# Altium schematic sheet PERIPHERAL_PORT_IO.SchDoc
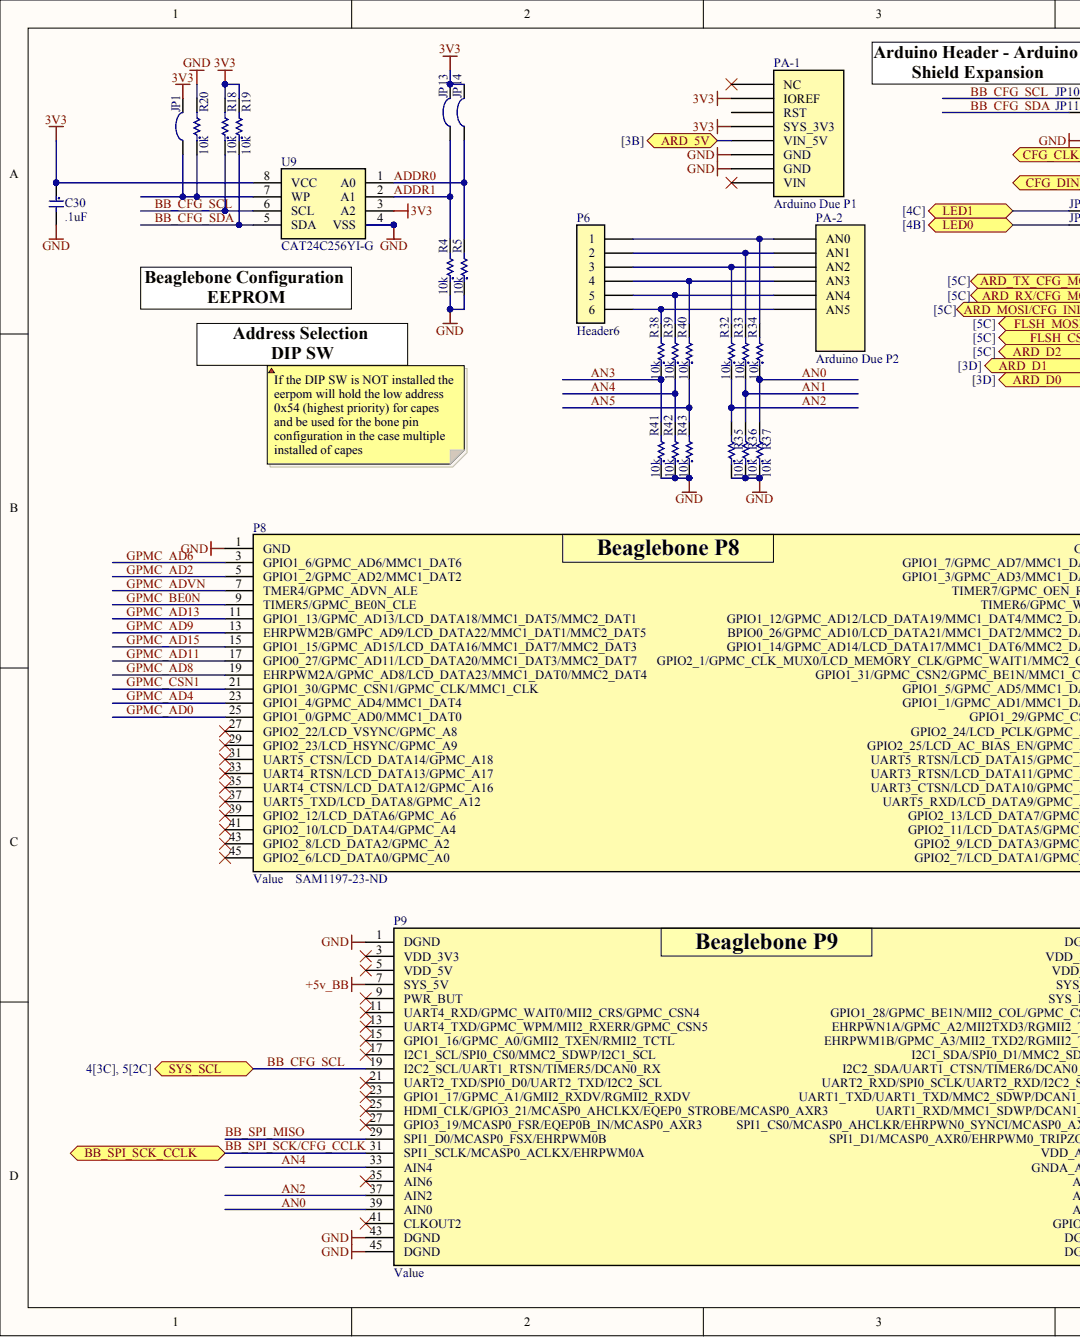
[diagram p0: PERIPHERAL_PORT_IO.SchDoc, left]
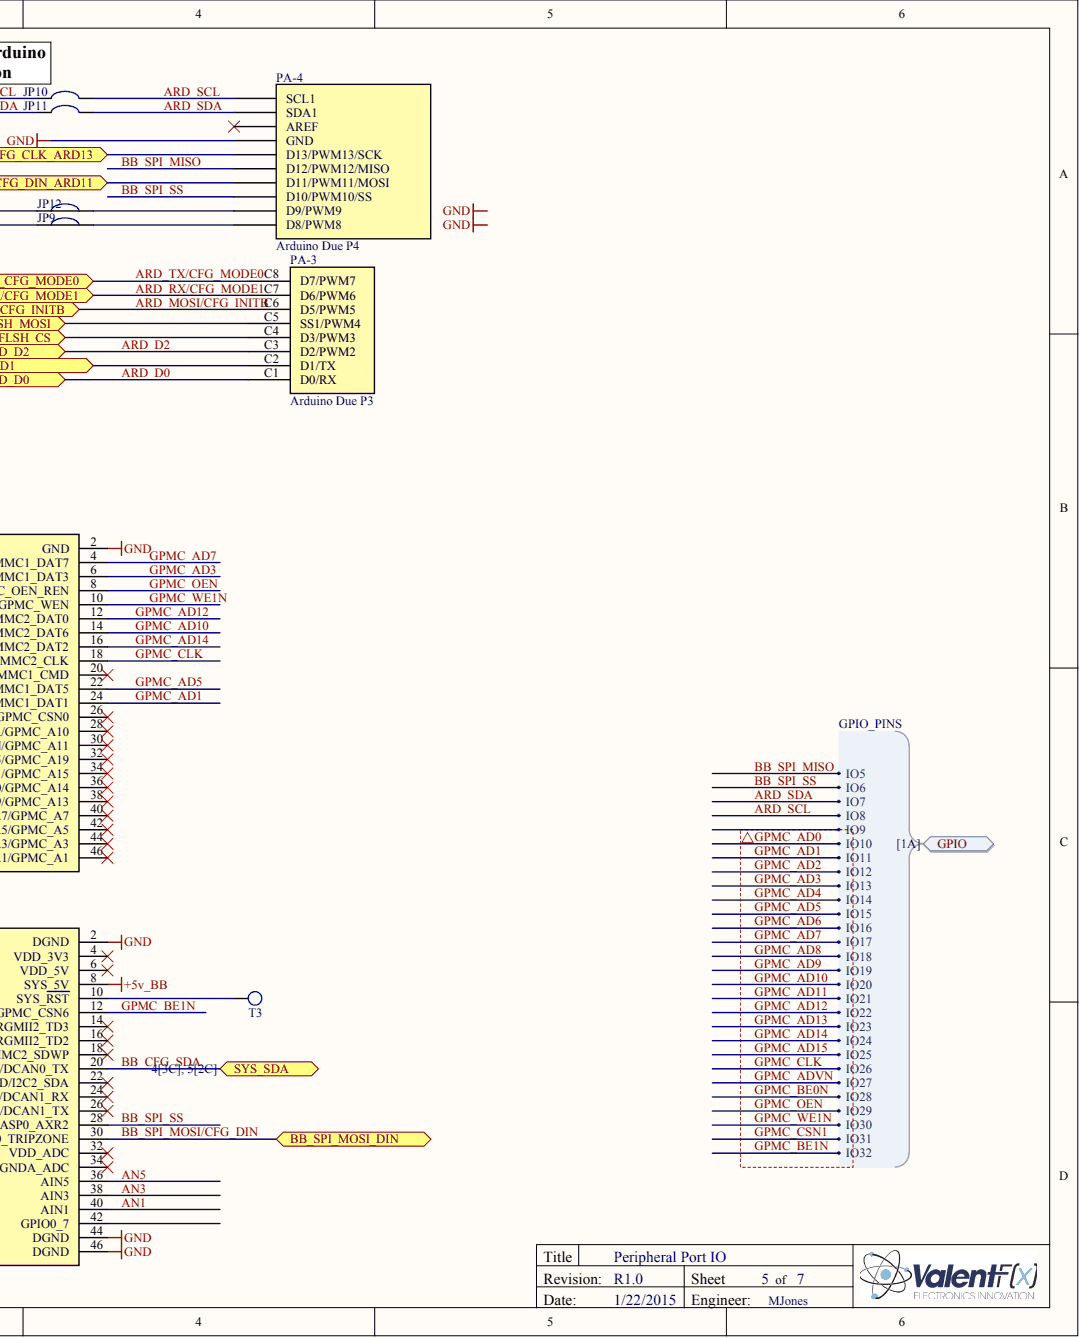
[diagram p1: PERIPHERAL_PORT_IO.SchDoc, right]

## Parts
C30  .1uF  [c0603]
JP1  Solder Jumper  [SOLDER_JUMPER]  (value not drawn)
JP9  Solder Jumper-NC  [SOLDER_JUMPER-NC]  (value not drawn)
JP10  Solder Jumper  [SOLDER_JUMPER]  (value not drawn)
JP11  Solder Jumper  [SOLDER_JUMPER]  (value not drawn)
JP12  Solder Jumper-NC  [SOLDER_JUMPER-NC]  (value not drawn)
JP13  Solder Jumper  [SOLDER_JUMPER]  (value not drawn)
JP14  Solder Jumper  [SOLDER_JUMPER]  (value not drawn)
P6  Header6  [HEADER_6_40_100 THRU SIP]  (no BOM)
P8  Value  Supplier Part Number 1: SAM1197-23-ND  [Beaglebone-SSQ]
P9  Value  [Beaglebone-SSQ]
PA-1  Arduino Due P1  [Arduino Due P1]
PA-2  Arduino Due P2  [Arduino Due P2]
PA-3  Arduino Due P3  [Arduino Due P3]
PA-4  Arduino Due P4  [Arduino Due P4]
R4  10k  [R0603]
R5  10k  [R0603]
R18  10k  [R0603]
R19  10k  [R0603]
R20  10k  [R0603]
R32  10k  [R0603]
R33  10k  [R0603]
R34  10k  [R0603]
R35  10k  [R0603]
R36  10k  [R0603]
R37  10k  [R0603]
R38  10k  [R0603]
R39  10k  [R0603]
R40  10k  [R0603]
R41  10k  [R0603]
R42  10k  [R0603]
R43  10k  [R0603]
T3  Test Point  [Tp 25-40]  (value not drawn)  (no BOM)
U9  CAT24C256YI-G  [TSSOP 8]

## Ports
ARD_5V, ARD_D0, ARD_D1, ARD_D2, ARD_MOSI/CFG_INITB, ARD_RX/CFG_MODE1, ARD_TX_CFG_MODE0, BB_SPI_MOSI_DIN, BB_SPI_SCK_CCLK, CFG_CLK_ARD13, CFG_DIN_ARD11, FLSH_CS, FLSH_MOSI, GPIO, GPIO.IO5, GPIO.IO6, GPIO.IO7, GPIO.IO8, GPIO.IO9, GPIO.IO10, GPIO.IO11, GPIO.IO12, GPIO.IO13, GPIO.IO14, GPIO.IO15, GPIO.IO16, GPIO.IO17, GPIO.IO18, GPIO.IO19, GPIO.IO20, GPIO.IO21, GPIO.IO22, GPIO.IO23, GPIO.IO24, GPIO.IO25, GPIO.IO26, GPIO.IO27, GPIO.IO28, GPIO.IO29, GPIO.IO30, GPIO.IO31, GPIO.IO32, LED0, LED1, SYS_SCL, SYS_SDA

## Nets
3V3: C30.1 JP1.2 JP13.2 JP14.2 PA-1.A2(IOREF) PA-1.A4(SYS_3V3) R18.2 R19.2 U9.3(A2) U9.8(VCC)
+5V_BB: P9.7(SYS_5V) P9.8(SYS_5V)
GND: C30.2 P8.1(GND) P8.2(GND) P9.1(DGND) P9.2(DGND) P9.43(DGND) P9.44(DGND) P9.45(DGND) P9.46(DGND) PA-1.A6(GND) PA-1.A7(GND) PA-4.D7(GND) R4.1 R5.1 R20.2 R35.1 R36.1 R37.1 R41.1 R42.1 R43.1 U9.4(VSS)
ADDR0: JP14.1 R5.2 U9.1(A0)
ADDR1: JP13.1 R4.2 U9.2(A1)
AN0: P9.39(AIN0) R34.1 R37.2
AN1: P9.40(AIN1) R33.1 R36.2
AN2: P9.37(AIN2) R32.1 R35.2
AN3: P9.38(AIN3) R38.1 R41.2
AN4: P9.33(AIN4) R39.1 R42.2
AN5: P9.36(AIN5) R40.1 R43.2
ARD_5V: PA-1.A5(VIN_5V) ; port ARD_5V
ARD_D0: PA-3.C1(D0/RX) ; port ARD_D0
ARD_D1: PA-3.C2(D1/TX) ; port ARD_D1
ARD_D2: PA-3.C3(D2/PWM2) ; port ARD_D2
ARD_MOSI/CFG_INITB: PA-3.C6(D5/PWM5) ; port ARD_MOSI/CFG_INITB
ARD_RX/CFG_MODE1: PA-3.C7(D6/PWM6) ; port ARD_RX/CFG_MODE1
ARD_SCL: JP10.2 PA-4.D10(SCL1) ; port GPIO
ARD_SDA: JP11.2 PA-4.D9(SDA1) ; port GPIO
ARD_TX/CFG_MODE0: PA-3.C8(D7/PWM7) ; port ARD_TX_CFG_MODE0
BB_CFG_SCL: JP10.1 P9.19(I2C2_SCL/UART1_RTSN/TIMER5/DCAN0_RX) R18.1 U9.6(SCL) ; port SYS_SCL
BB_CFG_SDA: JP11.1 P9.20(I2C2_SDA/UART1_CTSN/TIMER6/DCAN0_TX) R19.1 U9.5(SDA) ; port SYS_SDA
BB_SPI_MISO: P9.29(SPI1_D0/MCASP0_FSX/EHRPWM0B) PA-4.D5(D12/PWM12/MISO) ; port GPIO
BB_SPI_MOSI/CFG_DIN: P9.30(SPI1_D1/MCASP0_AXR0/EHRPWM0_TRIPZONE) ; port BB_SPI_MOSI_DIN
BB_SPI_SCK/CFG_CCLK: P9.31(SPI1_SCLK/MCASP0_ACLKX/EHRPWM0A) ; port BB_SPI_SCK_CCLK
BB_SPI_SS: P9.28(SPI1_CS0/MCASP0_AHCLKR/EHRPWN0_SYNCI/MCASP0_AXR2) PA-4.D3(D10/PWM10/SS) ; port GPIO
CFG_CLK_ARD13: PA-4.D6(D13/PWM13/SCK) ; port CFG_CLK_ARD13
CFG_DIN_ARD11: PA-4.D4(D11/PWM11/MOSI) ; port CFG_DIN_ARD11
FLSH_CS: PA-3.C4(D3/PWM3) ; port FLSH_CS
FLSH_MOSI: PA-3.C5(SS1/PWM4) ; port FLSH_MOSI
GPMC_AD0: P8.25(GPIO1_0/GPMC_AD0/MMC1_DAT0) ; port GPIO
GPMC_AD1: P8.24(GPIO1_1/GPMC_AD1/MMC1_DAT1) ; port GPIO
GPMC_AD2: P8.5(GPIO1_2/GPMC_AD2/MMC1_DAT2) ; port GPIO
GPMC_AD3: P8.6(GPIO1_3/GPMC_AD3/MMC1_DAT3) ; port GPIO
GPMC_AD4: P8.23(GPIO1_4/GPMC_AD4/MMC1_DAT4) ; port GPIO
GPMC_AD5: P8.22(GPIO1_5/GPMC_AD5/MMC1_DAT5) ; port GPIO
GPMC_AD6: P8.3(GPIO1_6/GPMC_AD6/MMC1_DAT6) ; port GPIO
GPMC_AD7: P8.4(GPIO1_7/GPMC_AD7/MMC1_DAT7) ; port GPIO
GPMC_AD8: P8.19(EHRPWM2A/GPMC_AD8/LCD_DATA23/MMC1_DAT0/MMC2_DAT4) ; port GPIO
GPMC_AD9: P8.13(EHRPWM2B/GMPC_AD9/LCD_DATA22/MMC1_DAT1/MMC2_DAT5) ; port GPIO
GPMC_AD10: P8.14(BPIO0_26/GPMC_AD10/LCD_DATA21/MMC1_DAT2/MMC2_DAT6) ; port GPIO
GPMC_AD11: P8.17(GPIO0_27/GPMC_AD11/LCD_DATA20/MMC1_DAT3/MMC2_DAT7) ; port GPIO
GPMC_AD12: P8.12(GPIO1_12/GPMC_AD12/LCD_DATA19/MMC1_DAT4/MMC2_DAT0) ; port GPIO
GPMC_AD13: P8.11(GPIO1_13/GPMC_AD13/LCD_DATA18/MMC1_DAT5/MMC2_DAT1) ; port GPIO
GPMC_AD14: P8.16(GPIO1_14/GPMC_AD14/LCD_DATA17/MMC1_DAT6/MMC2_DAT2) ; port GPIO
GPMC_AD15: P8.15(GPIO1_15/GPMC_AD15/LCD_DATA16/MMC1_DAT7/MMC2_DAT3) ; port GPIO
GPMC_ADVN: P8.7(TMER4/GPMC_ADVN_ALE) ; port GPIO
GPMC_BE0N: P8.9(TIMER5/GPMC_BE0N_CLE) ; port GPIO
GPMC_BE1N: P9.12(GPIO1_28/GPMC_BE1N/MII2_COL/GPMC_CSN6) ; port GPIO
GPMC_CLK: P8.18(GPIO2_1/GPMC_CLK_MUX0/LCD_MEMORY_CLK/GPMC_WAIT1/MMC2_CLK) ; port GPIO
GPMC_CSN1: P8.21(GPIO1_30/GPMC_CSN1/GPMC_CLK/MMC1_CLK) ; port GPIO
GPMC_OEN: P8.8(TIMER7/GPMC_OEN_REN) ; port GPIO
GPMC_WE1N: P8.10(TIMER6/GPMC_WEN) ; port GPIO
LED0: JP9.1 ; port LED0
LED1: JP12.1 ; port LED1
NetJP1_1: JP1.1 R20.1 U9.7(WP)
NetJP9_2: JP9.2 PA-4.D1(D8/PWM8)
NetJP12_2: JP12.2 PA-4.D2(D9/PWM9)
NetP6_1: P6.1 PA-2.B1(AN0) R34.2
NetP6_2: P6.2 PA-2.B2(AN1) R33.2
NetP6_3: P6.3 PA-2.B3(AN2) R32.2
NetP6_4: P6.4 PA-2.B4(AN3) R40.2
NetP6_5: P6.5 PA-2.B5(AN4) R39.2
NetP6_6: P6.6 PA-2.B6(AN5) R38.2
NetP9_10: P9.10(SYS_RST) T3.1
N00230: ; port GPIO

Not connected: P8 20(GPIO1_31/GPMC_CSN2/GPMC_BE1N/MMC1_CMD) 26(GPIO1_29/GPMC_CSN0) 27(GPIO2_22/LCD_VSYNC/GPMC_A8) 28(GPIO2_24/LCD_PCLK/GPMC_A10) 29(GPIO2_23/LCD_HSYNC/GPMC_A9) 30(GPIO2_25/LCD_AC_BIAS_EN/GPMC_A11) 31(UART5_CTSN/LCD_DATA14/GPMC_A18) 32(UART5_RTSN/LCD_DATA15/GPMC_A19) 33(UART4_RTSN/LCD_DATA13/GPMC_A17) 34(UART3_RTSN/LCD_DATA11/GPMC_A15) 35(UART4_CTSN/LCD_DATA12/GPMC_A16) 36(UART3_CTSN/LCD_DATA10/GPMC_A14) 37(UART5_TXD/LCD_DATA8/GPMC_A12) 38(UART5_RXD/LCD_DATA9/GPMC_A13) 39(GPIO2_12/LCD_DATA6/GPMC_A6) 40(GPIO2_13/LCD_DATA7/GPMC_A7) 41(GPIO2_10/LCD_DATA4/GPMC_A4) 42(GPIO2_11/LCD_DATA5/GPMC_A5) 43(GPIO2_8/LCD_DATA2/GPMC_A2) 44(GPIO2_9/LCD_DATA3/GPMC_A3) 45(GPIO2_6/LCD_DATA0/GPMC_A0) 46(GPIO2_7/LCD_DATA1/GPMC_A1); P9 3(VDD_3V3) 4(VDD_3V3) 5(VDD_5V) 6(VDD_5V) 9(PWR_BUT) 11(UART4_RXD/GPMC_WAIT0/MII2_CRS/GPMC_CSN4) 13(UART4_TXD/GPMC_WPM/MII2_RXERR/GPMC_CSN5) 14(EHRPWN1A/GPMC_A2/MII2TXD3/RGMII2_TD3) 15(GPIO1_16/GPMC_A0/GMII2_TXEN/RMII2_TCTL) 16(EHRPWM1B/GPMC_A3/MII2_TXD2/RGMII2_TD2) 17(I2C1_SCL/SPI0_CS0/MMC2_SDWP/I2C1_SCL) 18(I2C1_SDA/SPI0_D1/MMC2_SDWP) 21(UART2_TXD/SPI0_D0/UART2_TXD/I2C2_SCL) 22(UART2_RXD/SPI0_SCLK/UART2_RXD/I2C2_SDA) 23(GPIO1_17/GPMC_A1/GMII2_RXDV/RGMII2_RXDV) 24(UART1_TXD/UART1_TXD/MMC2_SDWP/DCAN1_RX) 25(HDMI_CLK/GPIO3_21/MCASP0_AHCLKX/EQEP0_STROBE/MCASP0_AXR3) 26(UART1_RXD/MMC1_SDWP/DCAN1_TX) 27(GPIO3_19/MCASP0_FSR/EQEP0B_IN/MCASP0_AXR3) 32(VDD_ADC) 34(GNDA_ADC) 35(AIN6) 41(CLKOUT2) 42(GPIO0_7); PA-1 A1(NC) A3(RST) A8(VIN); PA-4 D8(AREF)

## Library data of the parts
C30 = C0603 - CL10F104ZA8NNNC: CAP CER 0.1UF 25V Y5V 0603; Manufacturer: Samsung Electro-Mechanics America, Inc; Accept Alternative: Yes; Capacitance: 0.1µF; Temperature Coefficient: Y5V (F); Tolerance: -20%, +80%; Accept Compatible Replacement Part: Yes; Applications: General Purpose; Category: Capacitors; Component Kind: Standard; ComponentLink1Description: http://www.samsungsem.com/servlet/FileDownload?type=spec&file=CL10F104ZA8NNNC.pdf; ComponentLink1URL: http://www.samsungsem.com/servlet/FileDownload?type=spec&file=CL10F104ZA8NNNC.pdf; Features: -; Height - Seated (Max): -; Lead Spacing: -; Lead Style: -; Library Name: mCapacitors.SchLib; Manufacturer Part Number: CL10F104ZA8NNNC; Mounting Type: Surface Mount, MLCC; Operating Temperature: -30°C ~ 85°C; Package / Case: 0603 (1608 Metric); Packaging: Cut Tape (CT); Pricing 1: 1=0.1, 10=0.017, 25=0.012, 50=0.009, 100=0.0076, 250=0.00624, 500=0.00536, 1000=0.00417 (USD); Ratings: -; RoHS: RoHS Compliant; Size / Dimension: 0.063" L x 0.031" W (1.60mm x 0.80mm); Stock 1: 400907; Supplier 1: Digi-Key; Supplier Part Number 1: 1276-1011-1-ND; Thickness (Max): 0.035" (0.90mm); Value: .1uF; Voltage - Rated: 25V
JP1 JP10 JP11 JP13 JP14 = Solder Jumper: Solder/Configuration Jumper; Component Kind: Net Tie; Library Name: mConnector.SchLib; Manufacturer: n/a; Manufacturer Part Number: n/a; ROHS Certificate: n/a; Source: n/a; Source Part Number: n/a
JP9 JP12 = Solder Jumper-NC: Solder/Configuration Jumper; Component Kind: Net Tie; Library Name: mConnector.SchLib; Manufacturer: n/a; Manufacturer Part Number: n/a; ROHS Certificate: n/a; Source: n/a; Source Part Number: n/a
P6 = Header6: Header 6 pin; Library Name: mConnector.SchLib
P8 = Beagle_Bone_Black_P8-SSQ: Beagle_Bone_Black_P8; Manufacturer: Samtec Inc; Manufacturer Part Number: SSQ-123-03-G-D-RA; Connector Type: Receptacle; Number of Positions: 46; Number of Positions Loaded: All; Pitch: 0.100" (2.54mm); Category: Connectors, Interconnects; Color: Black; Component Kind: Standard; ComponentLink1Description: http://www.samtec.com/documents/webfiles/cpdf/SSQ-1XX-XX-XXX-X-XX-XXX-XX-X-MKT.pdf; ComponentLink1URL: http://www.samtec.com/documents/webfiles/cpdf/SSQ-1XX-XX-XXX-X-XX-XXX-XX-X-MKT.pdf; Contact Finish: Gold; Contact Finish Thickness: 20µin (0.51µm); Contact Type: Female Socket; Fastening Type: -; Features: -; Library Name: mConnector.SchLib; Mounting Type: Through Hole, Right Angle; Number of Rows: 2; Packaging: Bulk; Pricing 1: 1=0 (USD); RoHS: RoHS Compliant; Row Spacing: 0.100" (2.54mm); Stock 1: 0; Supplier 1: Digi-Key; Termination: Solder
P9 = Beagle_Bone_Black_P9-SSQ: Beagle_Bone_Black_P9; Manufacturer: Samtec Inc; Manufacturer Part Number: SSQ-123-03-G-D-RA; Connector Type: Receptacle; Number of Positions: 46; Number of Positions Loaded: All; Pitch: 0.100" (2.54mm); Category: Connectors, Interconnects; Color: Black; Component Kind: Standard; ComponentLink1Description: http://www.samtec.com/documents/webfiles/cpdf/SSQ-1XX-XX-XXX-X-XX-XXX-XX-X-MKT.pdf; ComponentLink1URL: http://www.samtec.com/documents/webfiles/cpdf/SSQ-1XX-XX-XXX-X-XX-XXX-XX-X-MKT.pdf; Contact Finish: Gold; Contact Finish Thickness: 20µin (0.51µm); Contact Type: Female Socket; Fastening Type: -; Features: -; Library Name: mConnector.SchLib; Mounting Type: Through Hole, Right Angle; Number of Rows: 2; Packaging: Bulk; Pricing 1: 1=0 (USD); RoHS: RoHS Compliant; Row Spacing: 0.100" (2.54mm); Stock 1: 0; Supplier 1: Digi-Key; Supplier Part Number 1: SAM1197-23-ND; Termination: Solder
PA-1 = Arduino Due P1: CONN HEADER FEMALE 8POS .1" GOLD; Manufacturer: Sullins Connector Solutions; Manufacturer Part Number: PPPC081LFBN-RC; Number of Positions: 8; Number of Positions Loaded: All; Pitch: 0.100" (2.54mm); Category: Connectors, Interconnects; Color: Black; Component Kind: Standard; ComponentLink1Description: http://www.sullinscorp.com/drawings/78_P(N)PxCxxxLFBN-RC,_10492-G.pdf; ComponentLink1URL: http://www.sullinscorp.com/drawings/78_P(N)PxCxxxLFBN-RC,_10492-G.pdf; Connector Type: Header; Contact Finish: Gold; Contact Finish Thickness: Flash; Contact Type: Female Socket; Fastening Type: -; Features: -; Library Name: mConnector.SchLib; Mounting Type: Through Hole; Number of Rows: 1; Packaging: Bulk; Pricing 1: 1=0.89, 10=0.69, 25=0.6436, 50=0.585, 100=0.5265, 250=0.4446, 500=0.4212, 1000=0.3744, 2500=0.31122 (USD); RoHS: RoHS Compliant; Row Spacing: -; Stock 1: 30245; Supplier 1: Digi-Key; Supplier Part Number 1: S7041-ND; Termination: Solder
PA-2 = Arduino Due P2: CONN HEADER FEMALE 6POS .1" GOLD; Manufacturer: Sullins Connector Solutions; Manufacturer Part Number: PPPC061LFBN-RC; Number of Positions: 6; Number of Positions Loaded: All; Pitch: 0.100" (2.54mm); Category: Connectors, Interconnects; Color: Black; Component Kind: Standard; ComponentLink1Description: http://www.sullinscorp.com/drawings/78_P(N)PxCxxxLFBN-RC,_10492-G.pdf; ComponentLink1URL: http://www.sullinscorp.com/drawings/78_P(N)PxCxxxLFBN-RC,_10492-G.pdf; Connector Type: Header; Contact Finish: Gold; Contact Finish Thickness: Flash; Contact Type: Female Socket; Fastening Type: -; Features: -; Library Name: mConnector.SchLib; Mounting Type: Through Hole; Number of Rows: 1; Packaging: Bulk; Pricing 1: 1=0.7, 10=0.546, 25=0.5088, 50=0.4626, 100=0.4163, 250=0.35152, 500=0.333, 1000=0.296, 2500=0.24605 (USD); RoHS: RoHS Compliant; Row Spacing: -; Stock 1: 9213; Supplier 1: Digi-Key; Supplier Part Number 1: S7039-ND; Termination: Solder
PA-3 = Arduino Due P3: CONN HEADER FEMALE 8POS .1" GOLD; Manufacturer: Sullins Connector Solutions; Manufacturer Part Number: PPPC081LFBN-RC; Number of Positions: 8; Number of Positions Loaded: All; Pitch: 0.100" (2.54mm); Category: Connectors, Interconnects; Color: Black; Component Kind: Standard; ComponentLink1Description: http://www.sullinscorp.com/drawings/78_P(N)PxCxxxLFBN-RC,_10492-G.pdf; ComponentLink1URL: http://www.sullinscorp.com/drawings/78_P(N)PxCxxxLFBN-RC,_10492-G.pdf; Connector Type: Header; Contact Finish: Gold; Contact Finish Thickness: Flash; Contact Type: Female Socket; Fastening Type: -; Features: -; Library Name: mConnector.SchLib; Mounting Type: Through Hole; Number of Rows: 1; Packaging: Bulk; Pricing 1: 1=0.89, 10=0.69, 25=0.6436, 50=0.585, 100=0.5265, 250=0.4446, 500=0.4212, 1000=0.3744, 2500=0.31122 (USD); RoHS: RoHS Compliant; Row Spacing: -; Stock 1: 30245; Supplier 1: Digi-Key; Supplier Part Number 1: S7041-ND; Termination: Solder
PA-4 = Arduino Due P4: CONN HEADER FMALE 10POS .1" GOLD; Manufacturer: Sullins Connector Solutions; Manufacturer Part Number: PPPC101LFBN-RC; Number of Positions: 10; Number of Positions Loaded: All; Pitch: 0.100" (2.54mm); Category: Connectors, Interconnects; Color: Black; Component Kind: Standard; ComponentLink1Description: http://www.sullinscorp.com/drawings/78_P(N)PxCxxxLFBN-RC,_10492-G.pdf; ComponentLink1URL: http://www.sullinscorp.com/drawings/78_P(N)PxCxxxLFBN-RC,_10492-G.pdf; Connector Type: Header; Contact Finish: Gold; Contact Finish Thickness: Flash; Contact Type: Female Socket; Fastening Type: -; Features: -; Library Name: mConnector.SchLib; Mounting Type: Through Hole; Number of Rows: 1; Packaging: Bulk; Pricing 1: 1=0.84, 10=0.699, 25=0.6476, 50=0.5828, 100=0.518, 250=0.45324, 500=0.4144, 1000=0.37555, 2500=0.3367 (USD); RoHS: RoHS Compliant; Row Spacing: -; Stock 1: 5570; Supplier 1: Digi-Key; Supplier Part Number 1: S7043-ND; Termination: Solder
R4 R5 R18-R20 R32-R43 = R0603 - CRCW060310K0FKEA: 10k Thick Film Resistors - SMD 1/10watt 10Kohms 1%; Manufacturer: Vishay Dale; Power (Watts): 0.1W, 1/10W; Temperature Coefficient: ±100ppm/°C; Category: Resistors; Component Kind: Standard; ComponentLink1Description: http://www.vishay.com/doc?20035; ComponentLink1URL: http://www.vishay.com/doc?20035; Composition: Thick Film; Features: -; Height: 0.020" (0.50mm); Library Name: mResistors.SchLib; Manufacturer Part Number: CRCW060310K0FKEA; Number of Terminations: 2; Package / Case: 0603 (1608 Metric); Packaging: Cut Tape (CT); Pricing 1: 10=0.081, 50=0.0436, 200=0.0251, 1000=0.01711 (USD); Resistance (Ohms): 10K; RoHS: RoHS Compliant; Size / Dimension: 0.061" L x 0.033" W (1.55mm x 0.85mm); Stock 1: 997856; Supplier 1: Digi-Key; Supplier Part Number 1: 541-10.0KHCT-ND; Tolerance: ±1%; Value: 10k
T3 = Test Point 2: Test Point Smaller Symbol; Library Name: mConnector.SchLib
U9 = EEPROM - CAT24C256YI-G: IC EEPROM 256KBIT 400KHZ 8TSSOP; Manufacturer: ON Semiconductor; Format - Memory: EEPROMs - Serial; Interface: I²C, 2-Wire Serial; Memory Size: 256K (32K x 8); Voltage - Supply: 1.8 V ~ 5.5 V; Category: Integrated Circuits (ICs); Component Kind: Standard; ComponentLink1Description: http://www.onsemi.com/pub_link/Collateral/CAT24C256-D.PDF; ComponentLink1URL: http://www.onsemi.com/pub_link/Collateral/CAT24C256-D.PDF; Library Name: mIC.SchLib; Manufacturer Part Number: CAT24C256YI-G; Memory Type: EEPROM; Operating Temperature: -40°C ~ 85°C; Package / Case: 8-TSSOP (0.173", 4.40mm Width); Packaging: Tube; Pricing 1: 1=0.97, 10=0.845, 25=0.7488, 100=0.6521, 250=0.56752, 500=0.483, 1000=0.3864, 2500=0.35018, 5000=0.32603 (USD); RoHS: RoHS Compliant; Speed: 400kHz; Stock 1: 840; Supplier 1: Digi-Key; Supplier Device Package: 8-TSSOP; Supplier Part Number 1: CAT24C256YI-GOS-ND; Value: CAT24C256YI-G
